# Altium schematic sheet JacDacMicroBitShieldLP 29.SchDoc
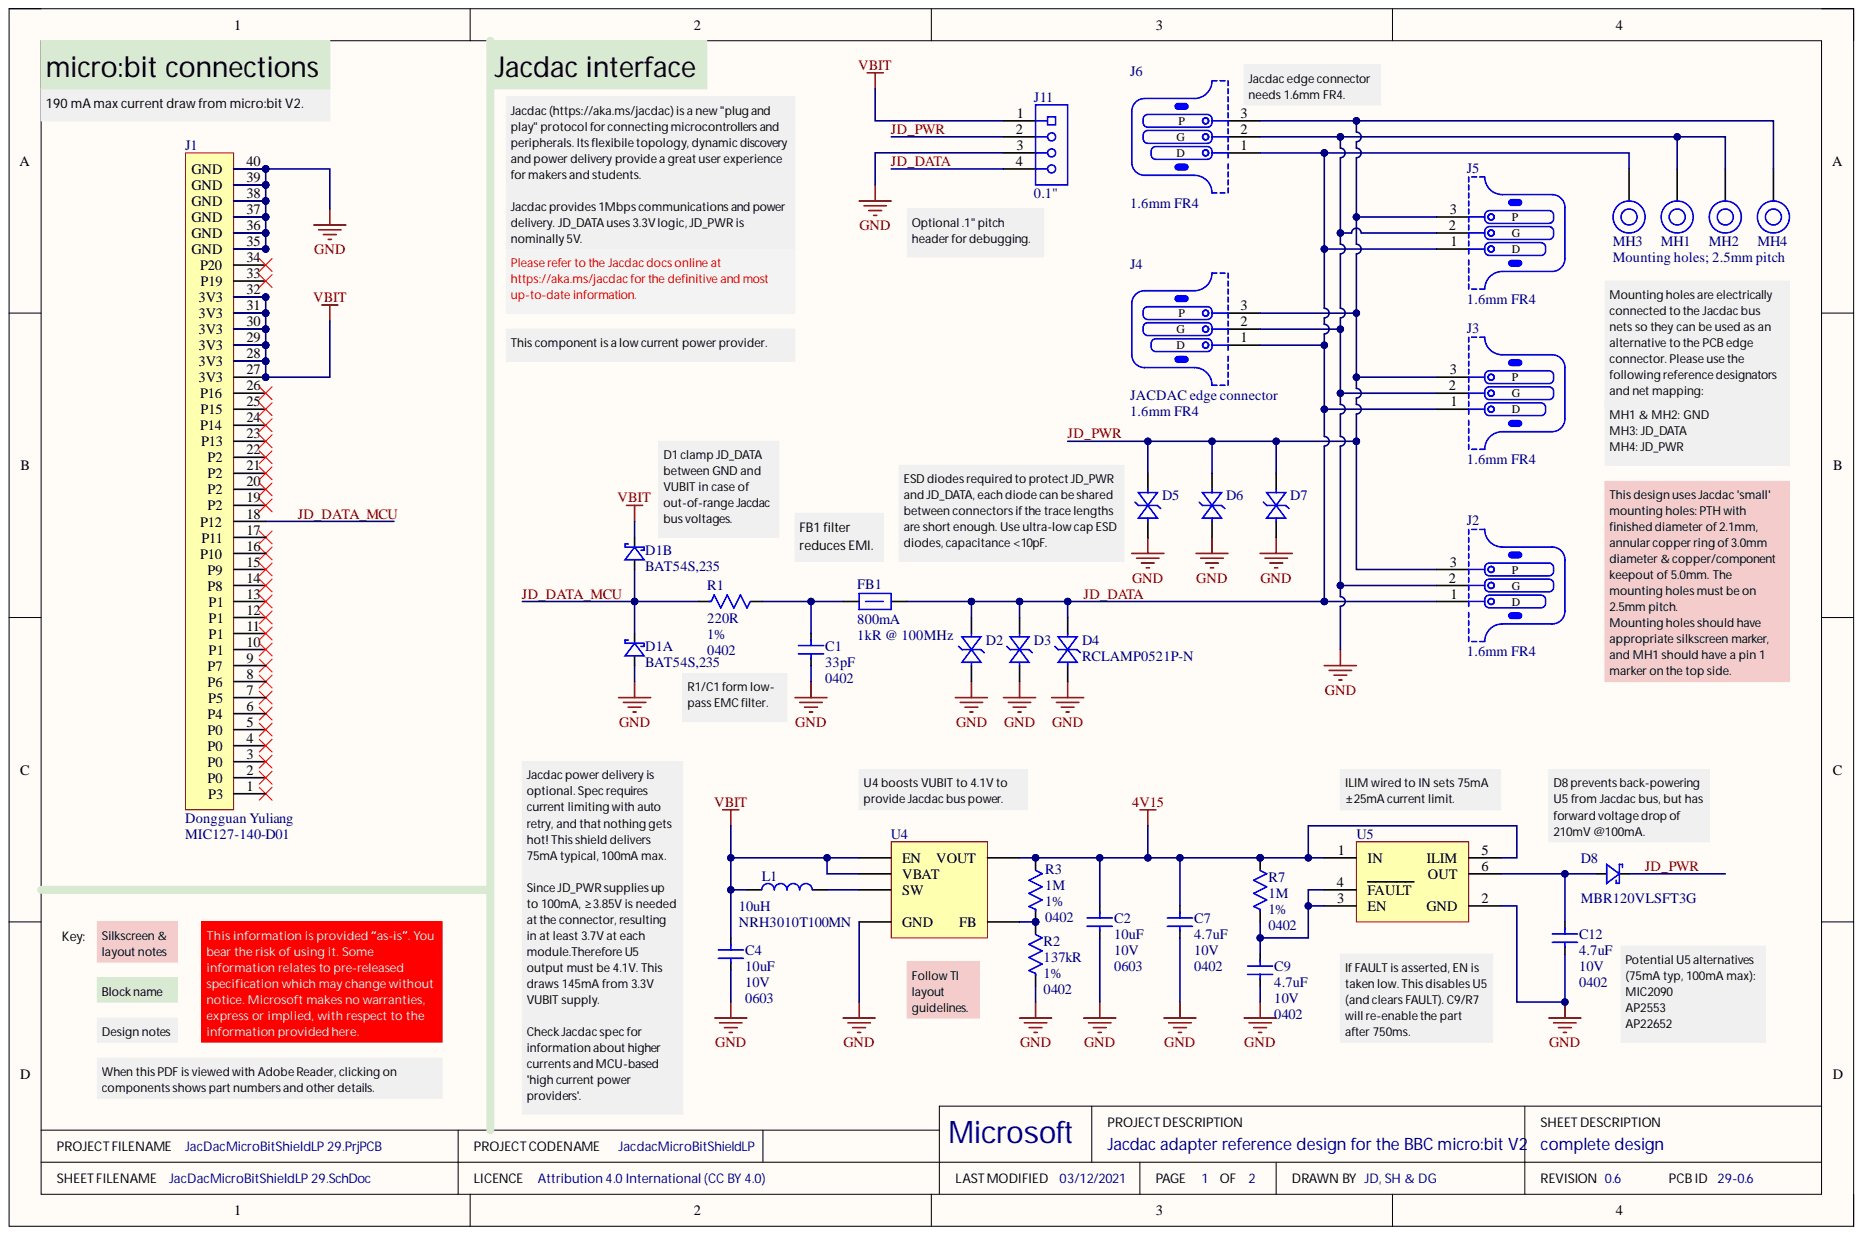
[diagram p0: JacDacMicroBitShieldLP 29.SchDoc]

## Parts
C1  Capacitance: 33pF  Case Code (Imperial): 0402
C2  Capacitance: 10uF  Case Code (Imperial): 0603  Voltage Rating: 10V
C4  Capacitance: 10uF  Case Code (Imperial): 0603  Voltage Rating: 10V
C7  Capacitance: 4.7uF  Case Code (Imperial): 0402  Voltage Rating: 10V
C9  Capacitance: 4.7uF  Case Code (Imperial): 0402  Voltage Rating: 10V
C12  Capacitance: 4.7uF  Case Code (Imperial): 0402  Voltage Rating: 10V
D1  Manufacturer Part Number: BAT54S,235  (parts A,B)
D2  (no value)
D3  (no value)
D4  Manufacturer Part Number: RCLAMP0521P-N
D5  (no value)
D6  (no value)
D7  (no value)
D8  Manufacturer Part Number: MBR120VLSFT3G
FB1  Current Rating: 800mA
J1  Manufacturer: Dongguan Yuliang  Manufacturer Part Number: MIC127-140-D01
J2  PCB Type: 1.6mm FR4
J3  PCB Type: 1.6mm FR4
J4  PCB Type: 1.6mm FR4
J5  PCB Type: 1.6mm FR4
J6  PCB Type: 1.6mm FR4
J11  (no value)
L1  Inductance: 10uH
MH1  (no value)
MH2  (no value)
MH3  (no value)
MH4  (no value)
R1  Resistance: 220R  Tolerance: 1%
R2  Case Code (Imperial): 0402  Resistance: 137kR  Tolerance: 1%
R3  Case Code (Imperial): 0402  Resistance: 1M  Tolerance: 1%
R7  Case Code (Imperial): 0402  Resistance: 1M  Tolerance: 1%
U4  (no value)
U5  (no value)

## Nets
4V15: C2.2 C7.2 R3.2 R7.2 U4.5(VOUT) U5.1(IN) U5.5(ILIM)
GND: C1.1 C2.1 C4.1 C7.1 C9.1 C12.1 D1.1 D2.2 D3.2 D4.2 D5.2 D6.2 D7.2 J1.35(GND) J1.36(GND) J1.37(GND) J1.38(GND) J1.39(GND) J1.40(GND) J2.2 J3.2 J4.2 J5.2 J6.2 J11.3 MH1.1 MH2.1 R2.1 U4.2(GND) U5.2(GND)
VBIT: C4.2 D1.2 J1.27(3V3) J1.28(3V3) J1.29(3V3) J1.30(3V3) J1.31(3V3) J1.32(3V3) J11.1 L1.1 U4.3(EN) U4.6(VBAT)
JD_DATA: D2.1 D3.1 D4.1 FB1.1 J2.1 J3.1 J4.1 J5.1 J6.1 J11.4 MH3.1
JD_DATA_MCU: D1.3 J1.18(P12) R1.1
JD_PWR: D5.1 D6.1 D7.1 D8.1 J2.3 J3.3 J4.3 J5.3 J6.3 J11.2 MH4.1
NetC1_2: C1.2 FB1.2 R1.2
NetC9_2: C9.2 R7.1 U5.3(EN) U5.4(FAULT)
NetC12_2: C12.2 D8.2 U5.6(OUT)
NetL1_2: L1.2 U4.1(SW)
NetR2_2: R2.2 R3.1 U4.4(FB)

Not connected: J1 1(P3) 2(P0) 3(P0) 4(P0) 5(P0) 6(P4) 7(P5) 8(P6) 9(P7) 10(P1) 11(P1) 12(P1) 13(P1) 14(P8) 15(P9) 16(P10) 17(P11) 19(P2) 20(P2) 21(P2) 22(P2) 23(P13) 24(P14) 25(P15) 26(P16) 33(P19) 34(P20)

## Library data of the parts
C1 = (no symbol name): CAP CER 33PF 50V NP0 0402; Manufacturer: Samsung; Manufacturer Part Number: CL05C330JB51PNC; Tolerance: 5%; Case Code (Metric): 1005; Case/Package: 0402; Category: Capacitors; ComponentLink1Description: Samsung datasheet online; ComponentLink1URL: http://www.samsungsem.com/kr/support/library/product-catalog/mlcc.jsp; Max Operating Temperature: 125°C; Min Operating Temperature: -55°C; Ratings: AEC-Q200; Supplier 1: Digi-Key; Supplier 2: Mouser; Supplier 3: Future Electronics; Supplier Part Number 1: 1276-6515-1-ND; Supplier Part Number 2: 187-CL05C330JB51PNC; Supplier Part Number 3: 6022520; Voltage Rating: 50V
C2 C4 = (no symbol name): CAP CER 10UF 10V X5R 0603; Manufacturer: Samsung; Manufacturer Part Number: CL10A106KP8NNNC; Material: Ceramic; Tolerance: 10%; Case Code (Metric): 1608; Case/Package: 0603; Category: Ceramic Capacitors; ComponentLink1Description: Online datasheet; ComponentLink1URL: https://datasheet.ciiva.com/26877/getdatasheetpartid-359551-26877881.pdf; Contact Plating: Tin; Dielectric: X5R; Max Operating Temperature: 85°C; Min Operating Temperature: -55°C; Supplier 1: Digi-Key; Supplier 2: Mouser; Supplier 3: Farnell; Supplier Part Number 1: 1276-1192-1-ND; Supplier Part Number 2: 187-CL10A106KP8NNNC; Supplier Part Number 3: 3013386; Voltage Rating (DC): 10V
C7 C9 C12 = (no symbol name): CAP CER 4.7UF 10V X5R 0402; Manufacturer: Samsung; Manufacturer Part Number: CL05A475MP5NRNC; Tolerance: 20%; Case Code (Metric): 1005; Case/Package: 0402; Category: Ceramic Capacitors; ComponentLink1Description: Online datasheet; ComponentLink1URL: https://datasheet.ciiva.com/25786/cl05a475mp5nrnc-25786963.pdf; Dielectric: X5R; Max Operating Temperature: 85°C; Min Operating Temperature: -55°C; RoHS Compliant: Yes; Series: CL; Supplier 1: Digi-Key; Supplier 2: Future Electronics; Supplier Part Number 1: 1276-1482-2-ND; Supplier Part Number 2: 2103694
D1 = (no symbol name): DIODE ARRAY SCHOTTKY 30V SOT23; Manufacturer: Nexperia; Category: Rectifier Diodes; ComponentLink1Description: NXP datasheet online; ComponentLink1URL: https://assets.nexperia.com/documents/data-sheet/BAT54_SER.pdf; Supplier 1: Digi-Key; Supplier 2: Mouser; Supplier 3: RSComponents; Supplier Part Number 1: 1727-1868-1-ND; Supplier Part Number 2: 771-BAT54S235; Supplier Part Number 3: 1707990
D2 D3 D5-D7 = (no symbol name): Bidirectional 5V (Max) 6V 16V 3.5A (8/20us) 0402 TVS RoHS; Manufacturer: Born Semiconductor; Manufacturer Part Number: RCLAMP0521P-N; ComponentLink1Description: Online datasheet; ComponentLink1URL: https://datasheet.lcsc.com/szlcsc/Bourne-Semicon-Shenzhen-RCLAMP0521P-N_C316043.pdf; Package: 0402; Supplier1: LCSC; Supplier1 Part Number: C316043
D4 = (no symbol name): Bidirectional 5V (Max) 6V 16V 3.5A (8/20us) 0402 TVS RoHS; Manufacturer: Born Semiconductor; ComponentLink1Description: Online datasheet; ComponentLink1URL: https://datasheet.lcsc.com/szlcsc/Bourne-Semicon-Shenzhen-RCLAMP0521P-N_C316043.pdf; Package: 0402; Supplier1: LCSC; Supplier1 Part Number: C316043
D8 = (no symbol name): DIODE SCHOTTKY 20V 1A SOD123FL; Manufacturer: ON Semiconductor; Element Configuration: Single; Forward Voltage: 340mV; Max Forward Surge Current (Ifsm): 45A; Max Reverse Leakage Current: 600uA; Polarity: Standard; Average Rectified Current: 1A; Category: Schottky Diodes; ComponentLink4Description: On Semi datasheet online; ComponentLink4URL: https://datasheet.ciiva.com/6380/mbr120vlsft1-d-6380910.pdf; Current Rating: 1A; Forward Current: 1A; Halogen Free: Halogen Free; Max Operating Temperature: 125°C; Max Repetitive Reverse Voltage (Vrrm): 20V; Max Reverse Voltage (DC): 20V; Max Surge Current: 45A; Min Operating Temperature: -65°C; Number of Pins: 2; Output Current: 1A; Peak Non-Repetitive Surge Current: 45A; Peak Reverse Current: 600uA; Supplier 1: Digi-Key; Supplier 2: Mouser; Supplier 3: RSComponents; Supplier Part Number 1: MBR120VLSFT3GOSCT-ND; Supplier Part Number 2: 863-MBR120VLSFT3G; Supplier Part Number 3: 1867479; Voltage Rating (DC): 20V
FB1 = (no symbol name): 0603 1k@100MHz 0.8A chip; Manufacturer: TDK; Manufacturer Part Number: MPZ1608S102ATA00; Core Material: Ferrite; DC Resistance (DCR): 300mR; Impedance: 1kR @ 100MHz; Tolerance: 25%; Case Code (Imperial): 0603; Case Code (Metric): 1608; Case/Package: 0603; ComponentLink2Description: datasheet online; ComponentLink2URL: https://www.mouser.co.uk/datasheet/2/400/eads_commercial_power_mpz1608_en-923650.pdf; ComponentLink3Description: datasheet; Max DC Current: 800mA; Supplier 1: Digi-Key; Supplier 2: Mouser; Supplier Part Number 1: 445-8694-1-ND; Supplier Part Number 2: 810-MPZ1608S102ATA00; ComponentLink3URL: Y:\System\Altium\Libraries 2014\TDK\Datasheets\eads_commercial_power_mpz1608_en-923650.pdf
J1 = (no symbol name): "Same plane" 1x40p 1.27mm Dongguan Yuliang BBC micro:bit edge connector; ComponentLink1Description: Yuliang drawing; ComponentLink2Description: Yuliang drawing online; ComponentLink2URL: http://www.dgyuliang.net/d/file/Produtcs/Customized%20Connector/MICRO%20BIT%20Connector/aff70b8859d81d58072ddeb323d41b1d.pdf; ComponentLink1URL: Y:\System\Altium\Libraries 2014\Yuliang\Datasheets\YULIANG-MIC127-140-D01.pdf
J2-J6 = (no symbol name): 3 pin jacdac edge connector 1.27mm pitch; Manufacturer Part Number: JACDAC_EDGE_CONNECTOR; ComponentLink1Description: Yuliang JACDAC cable connector drawing online; ComponentLink1URL: https://github.com/microsoft/jacdac-mdk/blob/main/connector/JACDAC-Connector-by-Yuliang-2020-11-28.pdf; ComponentLink2Description: JACDAC PCB edge connector spec onlin; ComponentLink2URL: https://github.com/microsoft/jacdac-mdk/blob/main/connector/JACDAC_PCB_Edge_Connector_Drawing_JD-PEC-01_rev_01.pdf
J11 = (no symbol name): 2.54mm, 4x1 way, vertical, square pin, gold plate; Manufacturer: Multicomp; Manufacturer Part Number: 2211S-04G; Contact Resistance: 20; Contact Termination: Solder; Contact Termination Type: Through Hole; Current Rating: 3; Gender: Header; Insulation Resistance: 1000; No. of Contacts: 4; No. of Rows: 1; Pitch Spacing: 2.54; Product Range: 2211; SVHC: No SVHC (17-Dec-2015); Voltage Rating V AC: 250; Withstand Voltage: 600V<!--FANDB--><div class="features-and-benefits-container"><div class="features-and-benefits-description"><span class="features-benefits-value">The 2211S-04G is a 4-pin 1-row PCB Header with gold plated copper alloy contacts and a black high temperature plastic (PBT) insulator. This board-to-board holder is ideal for through hole vertical mounting.</span></div><div class="features-and-benefits-list"><ul class="features-and-benefits-list-list"><li class="features-and-benefits-list-item">3A AC/DC Rated current</li><li class="features-and-benefits-list-item">250VAC/DC Rated voltage</li><li class="features-and-benefits-list-item">20; ComponentLink1Description: header strip datasheet; ComponentLink1URL: http://www.farnell.com/datasheets/1518407.pdf; Contact Material: Copper Alloy; Contact Plating: Gold Plated Contacts; Flammability Rating: UL94V-0; Lead Spacing: 2.54; Operating Temperature Max: 105; Operating Temperature Min: -40; Supplier 1: Farnell; Supplier Part Number 1: 1593413; Termination Method: Solder
L1 = (no symbol name): Inductor, Shielded, 10uH, 600mA, SMD; NRH Series; Inductance:10 H; Inductance Tolerance: 20%; Inductor Construction:Shielded; DC Resistance Max:0.35ohm; RMS Current (Irms):620mA; Saturation Current (Isat):600mA ;RoHS Compliant: Yes; Manufacturer: Taiyo Yuden; Manufacturer Part Number: NRH3010T100MN; Core Material: Ferrite; Current Rating: 620mA; DC Resistance (DCR): 350mR; Self Resonant Frequency: 34MHz; Test Frequency: 100kHz; Case/Package: 3010; Category: Fixed Inductors; ComponentLink2Description: Taiyo Yuden spec sheet online; ComponentLink2URL: https://datasheet.ciiva.com/1043/nrh3010t100mn-ss-1043273.pdf; ComponentLink3Description: Taiyo Yuden data sheet (2015) online; ComponentLink3URL: https://datasheet.ciiva.com/8002/wound04-e-8002182.pdf?src-supplier=Element14; Composition: Wirewound; Halogen Free: Halogen Free; Height - Seated (Max): 0.039inch; Max DC Current: 600mA; Max Operating Temperature: 120°C; Min Operating Temperature: -25°C; RMS Current (Irms): 620mA; Saturation Current: 600mA; Shielding: Shielded; Supplier 1: Digi-Key; Supplier 2: Mouser; Supplier Part Number 1: 587-2575-1-ND; Supplier Part Number 2: 963-NRH3010T100MN; Termination: SMD/SMT; Tolerance: 20%
MH1-MH4 = (no symbol name): Mounting Hole 5.0mm keepout, 3.0mm annualar ring and 2.1mm Drill PTH
R1 = (no symbol name): RES SMD 220 OHM 1% 1/16W 0402; Manufacturer: Uniroyal Elec; Manufacturer Part Number: 0402WGF2200TCE; Case Code (Imperial): 0402
R2 = (no symbol name): RES SMD 137K OHM 1% 1/16W 0402; Manufacturer: Yageo; Manufacturer Part Number: RC0402FR-07137KL; Max Power Dissipation: 63mW; Power Rating: 62.5mW; Temperature Coefficient: 100ppm/°C; Voltage Rating: 50V; Case Code (Metric): 1005; Case/Package: 0402; Category: Chip SMD Resistors; ComponentLink1Description: Online datasheet; ComponentLink1URL: https://datasheet.ciiva.com/16744/pyu-rc0402-51-rohs-l-6-r-16744009.pdf; Construction: Rectangular; Contact Plating: Tin,Matte; Features: Moisture Resistant; Max Operating Temperature: 155°C; Min Operating Temperature: -55°C; Number of Terminations: 2; Resistor Type: Thick Film; RoHS Compliant: Yes; Series: RC; Supplier 1: Digi-Key; Supplier 2: Avnet; Supplier 3: Mouser; Supplier Part Number 1: YAG2972CT-ND; Supplier Part Number 2: RC0402FR-07137KL; Supplier Part Number 3: 603-RC0402FR-07137KL; Technology: THICK FILM; Termination: SMD/SMT; Working Voltage: 50V
R3 R7 = (no symbol name): RES SMD 1M OHM 1% 1/16W 0402; Manufacturer: Uniroyal Elec; Manufacturer Part Number: 0402WGF1004TCE
U4 = (no symbol name): IC REG BST ADJ 0.22A SYNC SOT23; Manufacturer 1: Texas Instruments; Manufacturer Part Number: TLV61220DBVR; Efficiency: 95%; Max Input Voltage: 5.5V; Max Output Current: 400mA; Min Input Voltage: 700mV; Min Output Voltage: 1.8V; Number of Outputs: 1; Output Configuration: Positive; Output Current: 200mA; Output Type: Adjustable; Quiescent Current: 5uA; Switching Frequency: 2MHz; Synchronous Rectifier: Yes; Topology: Boost; Case/Package: SOT-23-6; Category: Voltage Regulators - Switching; ComponentLink5Description: Datasheet (online); ComponentLink5URL: https://www.ti.com/lit/ds/symlink/tlv61220.pdf; ComponentLink6Description: Datasheet (offline); Max Operating Temperature: 85°C; Max Output Voltage: 5.5V; Min Operating Temperature: -40°C; Number of Pins: 6; Output Voltage: 5.5V; Supplier 1: Digi-Key; Supplier 2: Farnell; Supplier 3: Mouser; Supplier Part Number 1: 296-30547-1-ND; Supplier Part Number 2: 3007284; Supplier Part Number 3: 595-TLV61220DBVR; ComponentLink6URL: Y:\System\Altium\Libraries 2014\TexasInstruments\datasheets\tlv61220.pdf
U5 = (no symbol name): POWER LOAD SWITCH, HIGH SIDE, SOT-23-6; Power Load Switch Type:High Side; Input Voltage:6.5V; Current Limit:1.7A; On State Resistance:0.085ohm; Distribution Switch Case Style:SOT-23; No. of Pins:6; Thermal Protection:Yes; On / Enable;RoHS Compliant: Yes; Manufacturer 1: Texas Instruments; Manufacturer Part Number: TPS2553DBVR; Max Input Voltage: 6.5V; Max Power Dissipation: 625mW; Min Input Voltage: 2.5V; Number of Outputs: 1; On-State Resistance: 85mR; Output Current: 1.5A; Case/Package: SOT-23; Category: Hot Swap Controllers; ComponentLink1Description: https://datasheet.ciiva.com/15108/getdatasheetpartid-297517-15108509.pdf?src-supplier=Verical; ComponentLink1URL: https://datasheet.ciiva.com/15108/getdatasheetpartid-297517-15108509.pdf?src-supplier=Verical; Max Operating Temperature: 85°C; Max Supply Voltage: 6.5V; Min Operating Temperature: -40°C; Min Supply Voltage: 2.5V; Number of Pins: 6; Supplier 1: Digi-Key; Supplier 2: Farnell; Supplier 3: Mouser; Supplier Part Number 1: 296-32464-1-ND; Supplier Part Number 2: 3124082; Supplier Part Number 3: 595-TPS2553DBVR; Weight: 0.00127oz
